# Altium schematic sheet POWER_SCH.SchDoc(POWER_SCH)
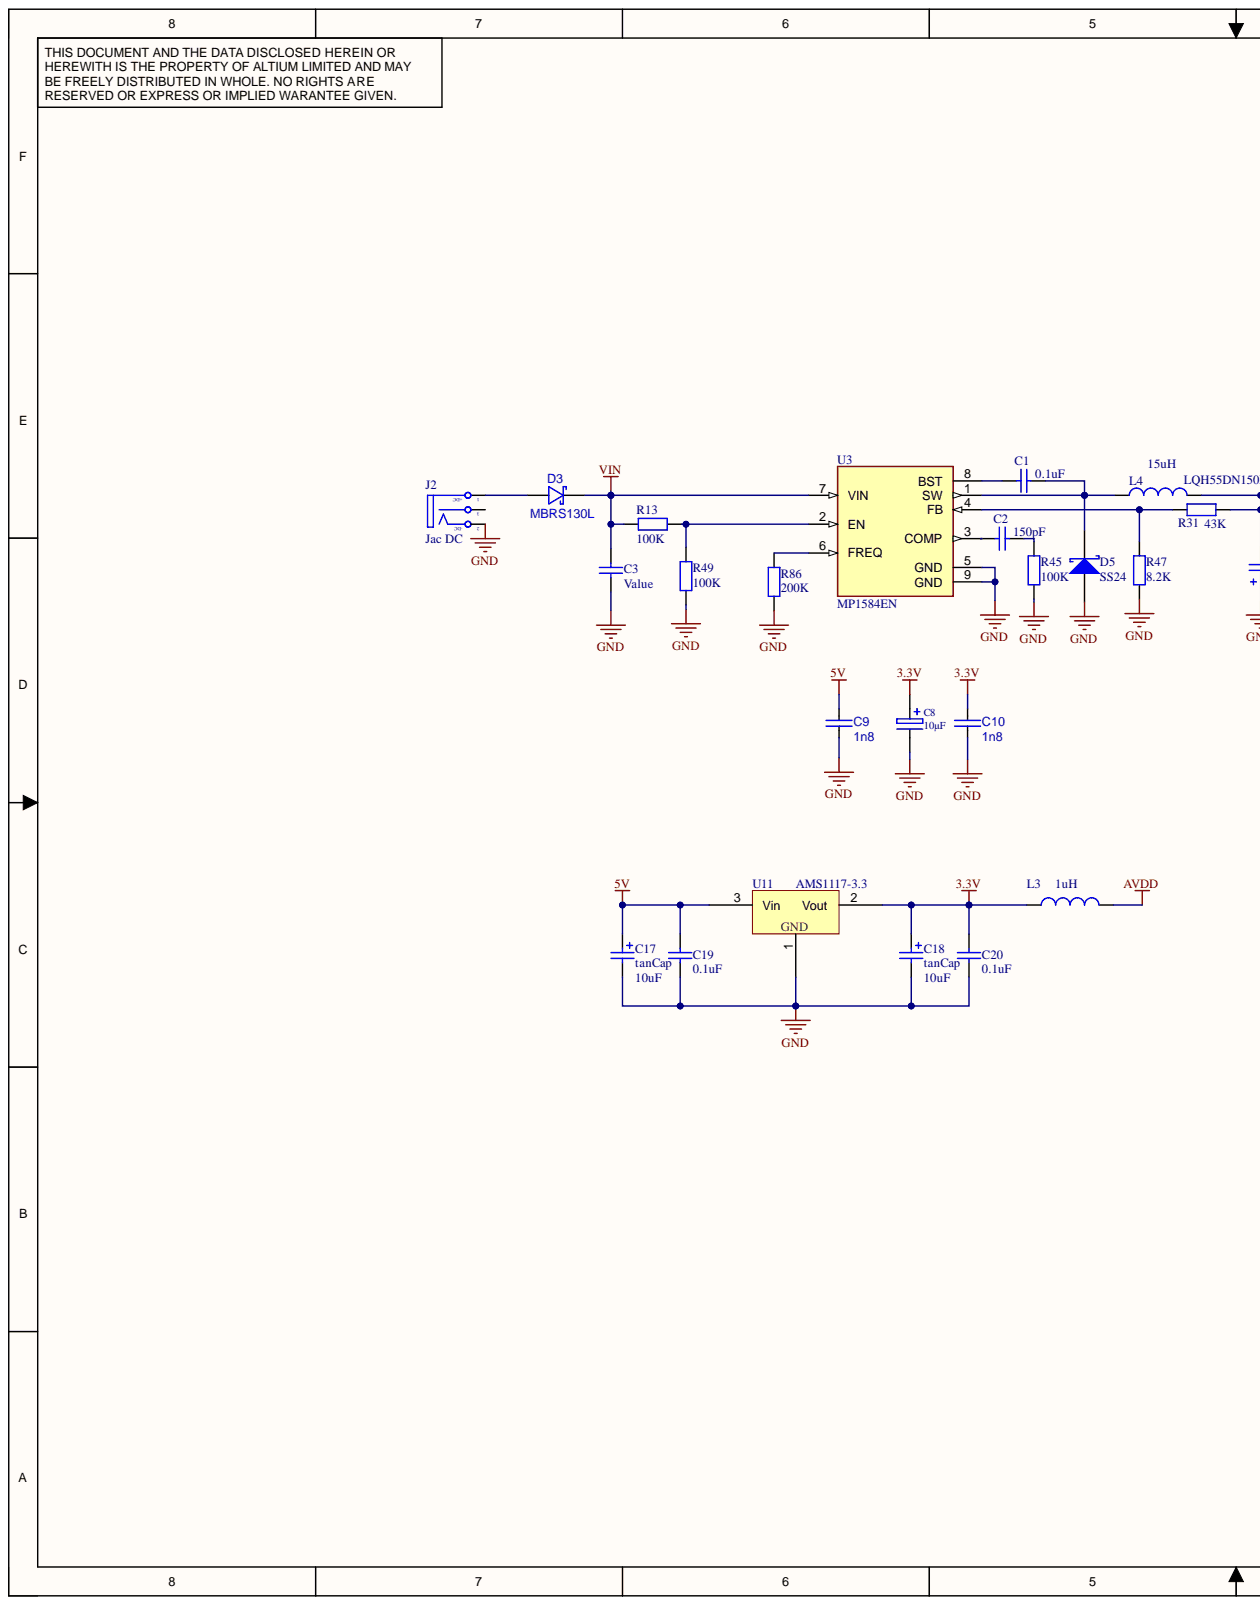
[diagram p0: POWER_SCH.SchDoc, left]
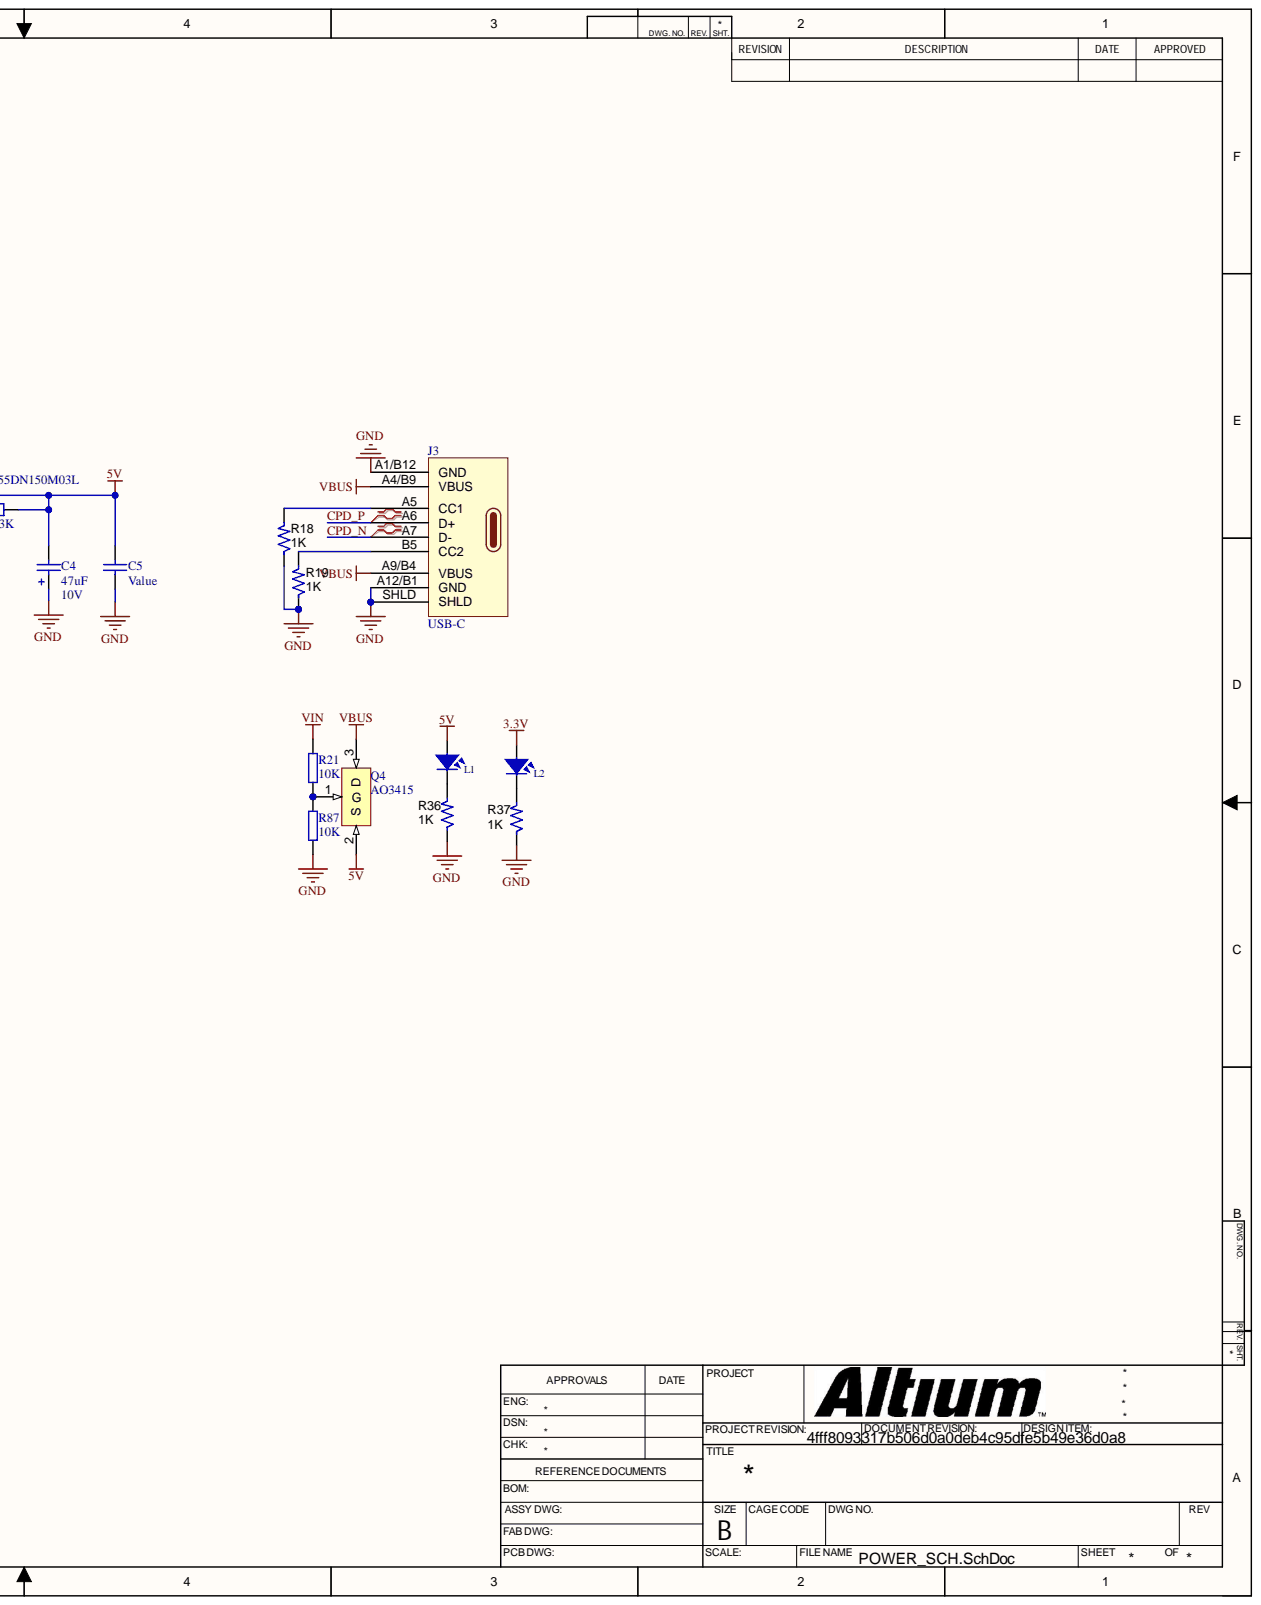
[diagram p1: POWER_SCH.SchDoc, right]

## Parts
C1  0.1uF  [CAP-0603]
C2  150pF  [CAP-0603]
C3  Value  [C1206]
C4  47uF  Voltage: 10V  [Tantal_B]
C5  Value  [C1206]
C8  CAP  [CAPMP3528X210N]  (value not drawn)
C9  value 1n8  [CAPC2012X135N]  (hidden comment: approved sub OK)
C10  value 1n8  [CAPC2012X135N]  (hidden comment: approved sub OK)
C17  tanCap  value 10uF  [C1206]
C18  tanCap  value 10uF  [C1206]
C19  value 0.1uF  [C0805]  (hidden comment: Cap)
C20  value 0.1uF  [C0805]  (hidden comment: Cap)
D3  MBRS130L  [DO-214AA]
D5  SS24  [SMB]
J2  Jac DC  [DC-IN]
J3  USB-C  [USB-C 8p]
L1  LED SMD  [SM-LED-0805-Orange]  (value not drawn)
L2  LED SMD  [SM-LED-0805-Orange]  (value not drawn)
L3  value 1uH  [C0805]  (hidden comment: Inductor)
L4  15uH  Marka: LQH55DN150M03L  [Inductor SMD 6.8*6.8*4.5]
Q4  AO3415  [SOT23-3]
R13  100K  [RES-0603]
R18  1K  [RESC1608N_ZERO]
R19  1K  [RESC1608N_ZERO]
R21  value 10K  [RESC1608N_ZERO]  (hidden comment: Resistor)
R31  43K  [RES-0603]
R36  1K  [RESC1608N_ZERO]
R37  1K  [RESC1608N_ZERO]
R45  100K  [RES-0603]
R47  8.2K  [RES-0603]
R49  100K  [RES-0603]
R86  200K  [RES-0603]
R87  value 10K  [RESC1608N_ZERO]  (hidden comment: Resistor)
U3  MP1584EN  [SOIC127P600X170-9N]
U11  AMS1117-3.3  [SOT223_M]

## Nets
3.3V: C8.1 C10.2 C18.1 C20.1 L2.1 L3.1 U11.2
5V: C4.2 C5.2 C9.2 C17.1 C19.1 L1.1 L4.2 Q4.2 R31.2 U11.3
AVDD: L3.2
GND: C3.1 C4.1 C5.1 C8.2 C9.1 C10.1 C17.2 C18.2 C19.2 C20.2 D5.1 J2.2 J3.A1/B12(GND) J3.A12/B1(GND) J3.SHLD R18.1 R19.1 R36.1 R37.1 R45.2 R47.2 R49.1 R86.1 R87.2 U3.5(GND) U3.9(GND) U11.1
VBUS: J3.A4/B9(VBUS) J3.A9/B4(VBUS) Q4.3
VIN: C3.2 D3.K R13.1 R21.1 U3.7(VIN)
CPD_N: J3.A7(D-)
CPD_P: J3.A6(D+)
NetC1_1: C1.1 U3.8(BST)
NetC1_2: C1.2 D5.2 L4.1 U3.1(SW)
NetC2_1: C2.1 U3.3(COMP)
NetC2_2: C2.2 R45.1
NetD3_A: D3.A J2.1
NetJ3_A5: J3.A5(CC1) R18.2
NetJ3_B5: J3.B5(CC2) R19.2
NetL1_2: L1.2 R36.2
NetL2_2: L2.2 R37.2
NetQ4_1: Q4.1 R21.2 R87.1
NetR13_2: R13.2 R49.2 U3.2(EN)
NetR31_1: R31.1 R47.1 U3.4(FB)
NetR86_2: R86.2 U3.6(FREQ)

Not connected: J2 3

## Library data of the parts
C1 C2 C19 C20 = Cap: Capacitor; Component Kind: Standard; LatestRevisionDate: 17-Jul-2002; LatestRevisionNote: Re-released for DXP Platform.; PackageDescription: Radial Cap, Thru-Hole; 2 Leads; 0.3 in Pin Spacing; PackageReference: RAD-0.3; Published: 8-Jun-2000; Signal Integrity: Cap; Simulation: CAP; Publisher: Altium Limited
  C1 C2: Library Name: mp1584.IntLib; links: links; price: price
  C19 C20: Library Name: Miscellaneous Devices.IntLib
C3 C5 = Cap SMD 1206: Component Kind: Standard; Library Name: My Library.SchLib
C4 = Cap Pol: Polarized Capacitor (Axial); Component Kind: Standard; LatestRevisionDate: 17-Jul-2002; LatestRevisionNote: Re-released for DXP Platform.; Library Name: mp1584.IntLib; links: https://www.promelec.ru/product/327656/; PackageDescription: Polarized Capacitor; 2 Leads; PackageReference: POLAR0.8; price: 12.53; Published: 8-Jun-2000; Signal Integrity: Cap Pol; Simulation: CAP; Publisher: Altium Limited
C8 = CAP Tatalium: Component Kind: Standard; Library Name: WIle_dev_kit.SCHLIB
C9 C10 = CAP C_0805: Capacitor SMD Cer 1.8nF 10% 50V X7R 0805; Manufacturer 1: AVX Corporation; Manufacturer Part Number 1: 08055C182KAT2A; Ratings: 50V; X7R; SCDType: source*; Component Kind: Standard; Operating Temperature: -55°C ~ 125°C; Package / Case: 0805 (2012 metric); Supplier 1: Digi-Key; Supplier Part Number 1: 478-1374-1-ND; Tolerance: ±10%; Library Name: WIle_dev_kit.SCHLIB
C17 C18 = Cap Pol2: Polarized Capacitor (Axial); Component Kind: Standard; LatestRevisionDate: 17-Jul-2002; LatestRevisionNote: Re-released for DXP Platform.; Library Name: Miscellaneous Devices.IntLib; PackageDescription: Polarized Capacitor; 2 Leads; PackageReference: POLAR0.8; Published: 8-Jun-2000; Signal Integrity: Cap Pol; Simulation: CAP; Publisher: Altium Limited
D3 = DIODE_SCHOTTKY_MBRS130L: Diode Schottky SMD 30V 2A DO-214AA; Manufacturer 1: Fairchild Semiconductor; Material: Si; Ratings: 30Vr; 2A; SCDType: source; Component Kind: Standard; Library Name: My library altium.IntLib; Manufacturer Part Number 1: MBRS130L; Package / Case: DO-214AA, SMB; Supplier 1: Digi-Key; Supplier Part Number 1: MBRS130LFSCT-ND
D5 = D Schottky: Schottky Diode; Code_JEDEC: DO-214-AA; Component Kind: Standard; LatestRevisionDate: 17-Jul-2002; LatestRevisionNote: Re-released for DXP Platform.; Library Name: mp1584.IntLib; PackageDescription: DO-214-AA/SMB; 2 C-Bend Leads; Body 5.3 x 3.6 mm, inc. leads (LxW); PackageReference: SMB; Published: 8-Jun-2000; Signal Integrity: D Schottky; Simulation: SKYDIODE; Publisher: Altium Limited
J2 = DCIN: Jac DC input; Component Kind: Standard; Value: Jac DC; Library Name: WIle_dev_kit.SCHLIB
L1 L2 = LED SMD 0805: Component Kind: Standard; Library Name: WIle_dev_kit.SCHLIB
L3 = Inductor: Code_IEC: 1005; Code_JEITA: 0402; Component Kind: Standard; Description: Inductor; LatestRevisionDate: 29-May-2009; LatestRevisionNote: IPC-7351 Footprint Added.; Library Name: Miscellaneous Devices.IntLib; PackageDescription: Chip Inductor; PackageReference: 0402-A; Published: 8-Jun-2000; Signal Integrity: Inductor; Simulation: INDUCTOR; Publisher: Altium Limited
L4 = Inductor: Component Kind: Standard; Library Name: mp1584.IntLib; links: https://www.promelec.ru/product/318749/; price: 68.07; Signal Integrity: Inductor; Simulation: INDUCTOR
R13 R31 R45 R47 R49 R86 = Res: Component Kind: Standard; Library Name: mp1584.IntLib; links: links; price: price
R18 R19 R36 R37 = RES_0603: Resistor SMD chip 0.0 Ohm 0.1W 0603; Manufacturer 1: Vishay/Dale; Manufacturer Part Number 1: CRCW06030000Z0EA; Ratings: 1/10W; SCDType: spec; Tolerance: ±5%; Component Kind: Standard; ComponentLink1Description: http://www.vishay.com/doc?20035; ComponentLink1URL: http://www.vishay.com/doc?20035; ComponentLink2Description: http://industrial.panasonic.com/www-cgi/jvcr13pz.cgi?E+PZ+3+AOA0001+ERJ3GEY0R00V+7+WW; ComponentLink2URL: http://industrial.panasonic.com/www-cgi/jvcr13pz.cgi?E+PZ+3+AOA0001+ERJ3GEY0R00V+7+WW; ComponentLink3Description: http://www.yageo.com/documents/recent/PYu-AC_51_RoHS_L_2.pdf; ComponentLink3URL: http://www.yageo.com/documents/recent/PYu-AC_51_RoHS_L_2.pdf; Manufacturer 2: Panasonic Electronic Components; Manufacturer 3: Yageo; Manufacturer Part Number 2: ERJ-3GEY0R00V; Manufacturer Part Number 3: AC0603JR-070RL; Package / Case: 0603 (1608metric); RoHS 2: RoHS Compliant; RoHS 3: RoHS Compliant; Supplier 1: Digi-Key; Supplier 2: Digi-Key; Supplier 3: Digi-Key; Supplier Part Number 1: 541-0.0GCT-ND; Supplier Part Number 2: P0.0GTR-ND; Supplier Part Number 3: 311-0.0LECT-ND; Library Name: WIle_dev_kit.SCHLIB
R21 R87 = RES-SM-0603-10K: RES SM 0603 10K; Component Kind: Standard; Library Name: electronpowered.SchLib; Supplier 1: Farnell; Supplier 2: Digi-Key; Supplier Part Number 1: 9330399; Supplier Part Number 2: 541-10.0KHCT-ND
U3 = MP1584EN: Buck Switching Regulator IC Positive Adjustable 0.8V 1 Output 3A 8-SOIC _0.154, 3.90mm Width_ Exposed Pad; MF: Monolithic Power; Availability: Unavailable; Component Kind: Standard; Library Name: MP1584EN.IntLib; MP: MP1584EN; Package: SOIC-8 Monolithic Power; Price: None; SnapEDA_Link: https://www.snapeda.com/parts/MP1584EN/Monolithic%20Power%20Systems%20Inc./view-part/891721/?ref=snap
U11 = AMS1117: AMS1117-; Component Kind: Standard; Library Name: qyh.SchLib
J3 = USB-C: Component Kind: Standard; Library Name: WIle_dev_kit.SCHLIB
Q4 = AO3415: Component Kind: Standard; Library Name: WIle_dev_kit.SCHLIB
